# Altium schematic sheet [2]Connectors_and_screen.SchDoc([2]Connectors_and_screen)
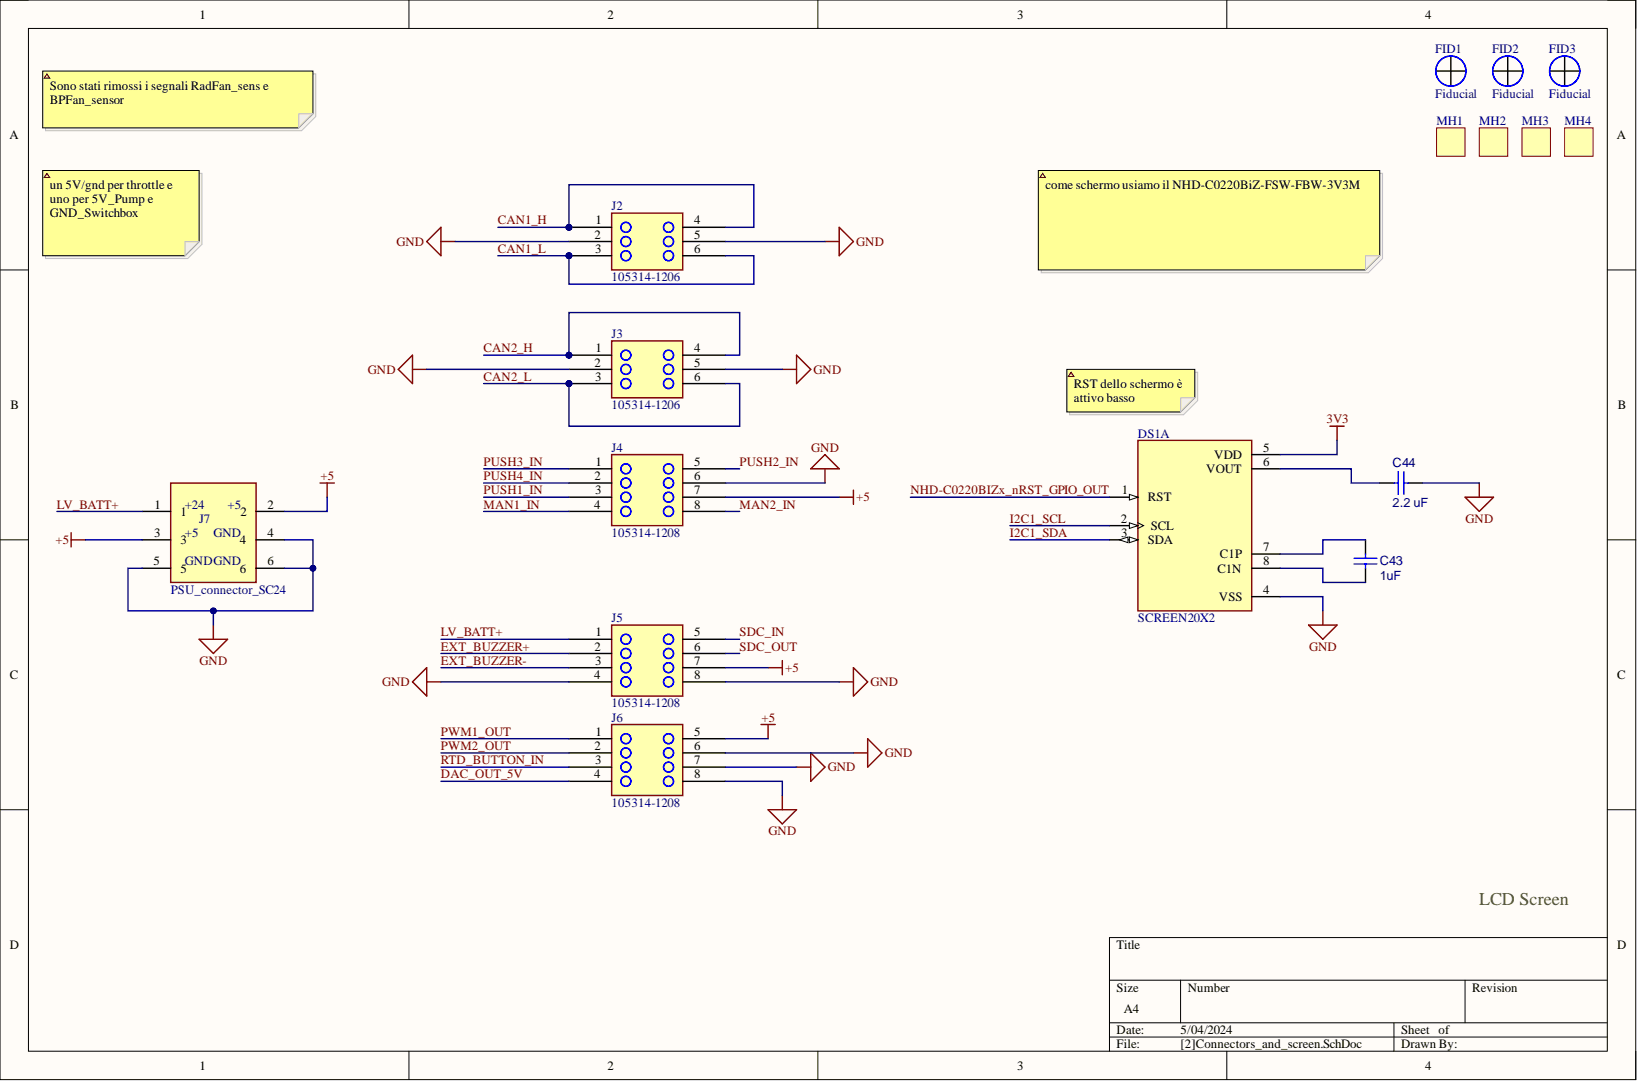
[diagram p0: [2]Connectors_and_screen.SchDoc]

## Parts
C43  1uF
C44  2.2 uF
DS1  SCREEN20X2  (part A)
FID1  Fiducial
FID2  Fiducial
FID3  Fiducial
J2  105314-1206
J3  105314-1206
J4  105314-1208
J5  105314-1208
J6  105314-1208
J7  PSU_connector_SC24
MH1  MH_M3  (value not drawn)
MH2  MH_M3  (value not drawn)
MH3  MH_M3  (value not drawn)
MH4  MH_M3  (value not drawn)

## Nets
3V3: DS1.5(VDD)
+5: J4.7 J5.7 J6.5 J7.2 J7.3
GND: C44.1 DS1.4(VSS) J2.2 J2.5 J3.2 J3.5 J4.6 J5.4 J5.8 J6.6 J6.7 J6.8 J7.4 J7.5 J7.6
CAN1_H: J2.1 J2.4
CAN1_L: J2.3 J2.6
CAN2_H: J3.1 J3.4
CAN2_L: J3.3 J3.6
DAC_OUT_5V: J6.4
EXT_BUZZER+: J5.2
EXT_BUZZER-: J5.3
I2C1_SCL: DS1.2(SCL)
I2C1_SDA: DS1.3(SDA)
LV_BATT+: J5.1 J7.1
MAN1_IN: J4.4
MAN2_IN: J4.8
NHD-C0220BIZx_nRST_GPIO_OUT: DS1.1(RST)
PUSH1_IN: J4.3
PUSH2_IN: J4.5
PUSH3_IN: J4.1
PUSH4_IN: J4.2
PWM1_OUT: J6.1
PWM2_OUT: J6.2
RTD_BUTTON_IN: J6.3
SDC_IN: J5.5
SDC_OUT: J5.6
NetC43_1: C43.1 DS1.8(C1N)
NetC43_2: C43.2 DS1.7(C1P)
NetC44_2: C44.2 DS1.6(VOUT)

## Library data of the parts
C43 = CMP-001-00085-5: VJ0805Y105KXXTW1BC; Tech: SMD; Component Kind: Standard; ComponentLink1Description: Website; Package: 0805; Library Name: EMEA- Squadra Corse PoliTO-  Politecnico di Torino
C44 = CMP-001-00085-5: VJ0805Y225KXJTW1BC; Tech: SMD; Component Kind: Standard; ComponentLink1Description: Website; Package: 0805; Library Name: EMEA- Squadra Corse PoliTO-  Politecnico di Torino
DS1 = CMP-149-000003-4: NHD-C0220BIZ-FSW-FBW-3V3M; Component Kind: Standard; Library Name: EMEA- Squadra Corse PoliTO-  Politecnico di Torino
FID1-FID3 = CMP-00028-00007-10: Component Kind: Standard; Library Name: EMEA- Squadra Corse PoliTO-  Politecnico di Torino
J2 J3 = CMP-000003-4: NanoFit 6 Pin, Right Angle, Gold Plating; Component Kind: Standard; Library Name: EMEA- Squadra Corse PoliTO-  Politecnico di Torino
J4-J6 = CMP-000005-1: Nano-Fit Right Angle Header; Through Hole; 2.50mm; Dual Row; 8 Circuit; Gold Plating; Component Kind: Standard; Library Name: EMEA- Squadra Corse PoliTO-  Politecnico di Torino
J7 = CMP-00019-4: 79107-7002; Component Kind: Standard; Library Name: EMEA- Squadra Corse PoliTO-  Politecnico di Torino
MH1-MH4 = CMP-00028-00008-3: Mountinh Hole M3, 3,5 mm hole, 6,5 mm external; Component Kind: Standard; Library Name: EMEA- Squadra Corse PoliTO-  Politecnico di Torino
